# Altium schematic sheet Sheet2.SchDoc(Sheet2)
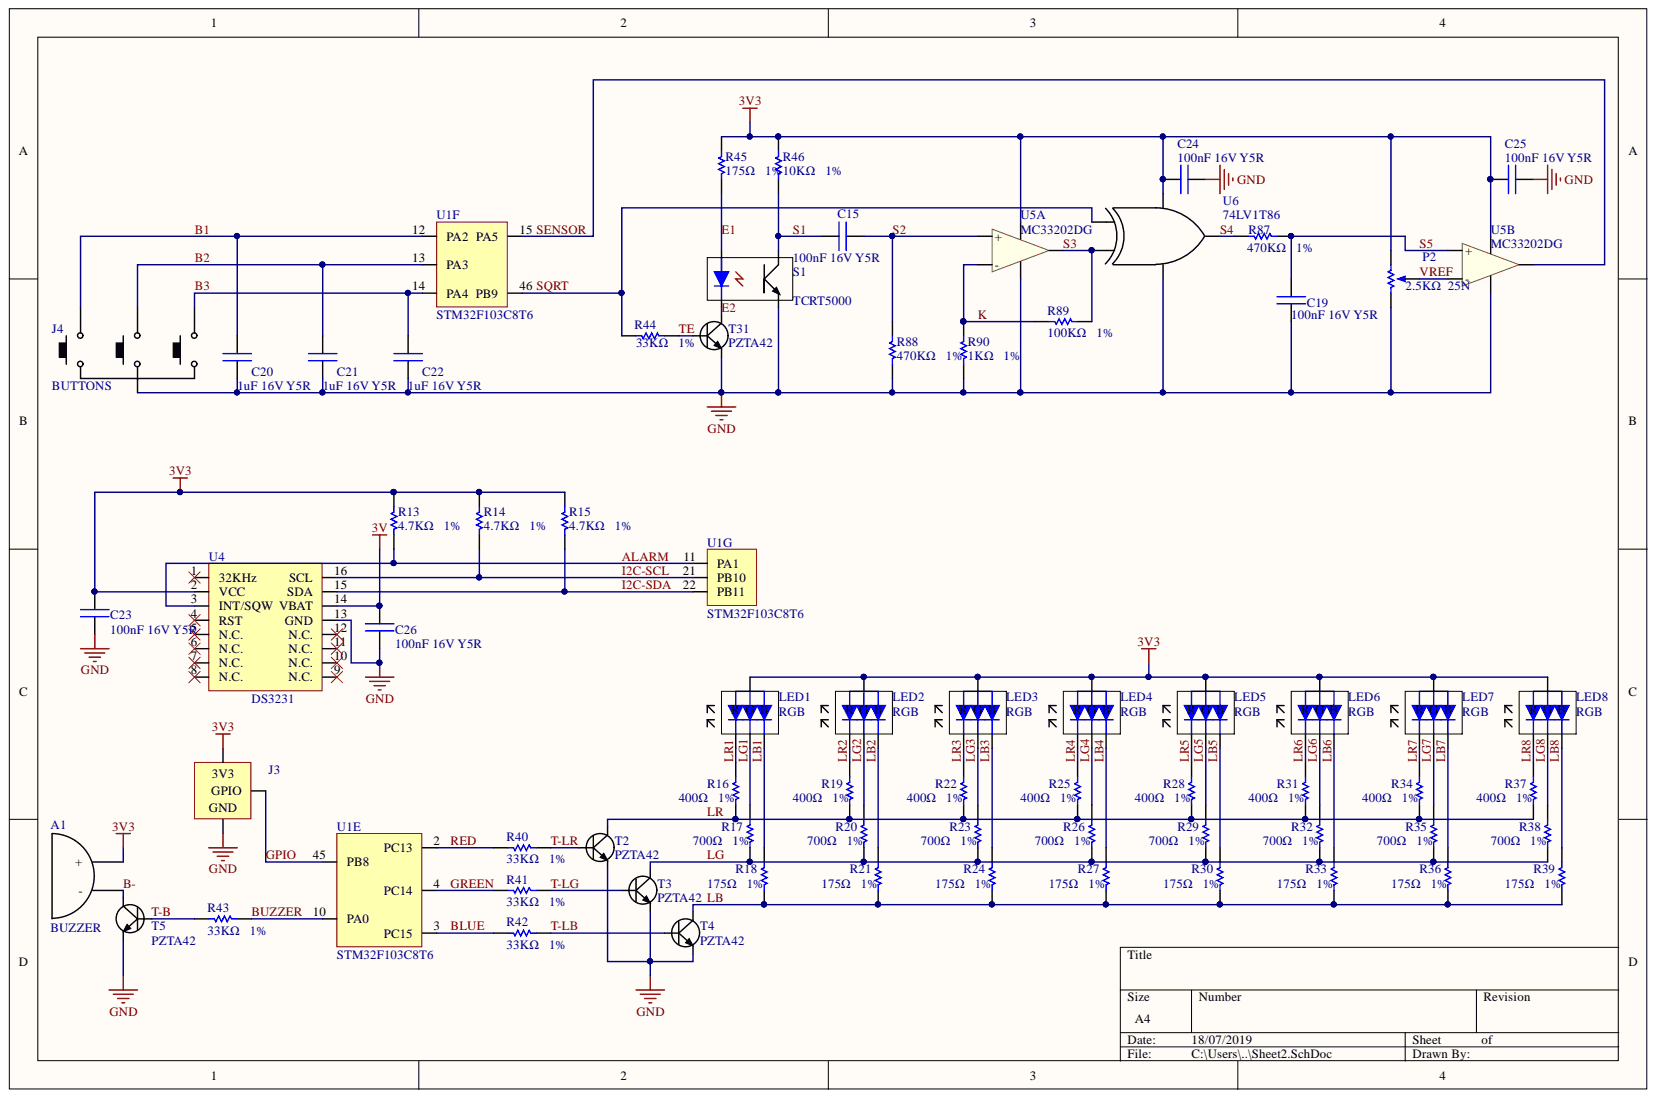
[diagram p0: Sheet2.SchDoc]

## Parts
A1  BUZZER  [BUZZER]
C15  100nF 16V Y5R  [0603]
C19  100nF 16V Y5R  [0603]
C20  1uF 16V Y5R  [0603]
C21  1uF 16V Y5R  [0603]
C22  1uF 16V Y5R  [0603]
C23  100nF 16V Y5R  [0603]
C24  100nF 16V Y5R  [0603]
C25  100nF 16V Y5R  [0603]
C26  100nF 16V Y5R  [0603]
J3  GPIO  [PIN-HEADERx3]
J4  BUTTONS  [BORNERAx4]
LED1  RGB  [1205-RGB]
LED2  RGB  [1205-RGB]
LED3  RGB  [1205-RGB]
LED4  RGB  [1205-RGB]
LED5  RGB  [1205-RGB]
LED6  RGB  [1205-RGB]
LED7  RGB  [1205-RGB]
LED8  RGB  [1205-RGB]
P2  2.5KΩ  25N  [3296W]
R13  4.7KΩ   1%  [0603]
R14  4.7KΩ   1%  [0603]
R15  4.7KΩ   1%  [0603]
R16  400Ω   1%  [0603]
R17  700Ω   1%  [0603]
R18  175Ω   1%  [0603]
R19  400Ω   1%  [0603]
R20  700Ω   1%  [0603]
R21  175Ω   1%  [0603]
R22  400Ω   1%  [0603]
R23  700Ω   1%  [0603]
R24  175Ω   1%  [0603]
R25  400Ω   1%  [0603]
R26  700Ω   1%  [0603]
R27  175Ω   1%  [0603]
R28  400Ω   1%  [0603]
R29  700Ω   1%  [0603]
R30  175Ω   1%  [0603]
R31  400Ω   1%  [0603]
R32  700Ω   1%  [0603]
R33  175Ω   1%  [0603]
R34  400Ω   1%  [0603]
R35  700Ω   1%  [0603]
R36  175Ω   1%  [0603]
R37  400Ω   1%  [0603]
R38  700Ω   1%  [0603]
R39  175Ω   1%  [0603]
R40  33KΩ   1%  [0603]
R41  33KΩ   1%  [0603]
R42  33KΩ   1%  [0603]
R43  33KΩ   1%  [0603]
R44  33KΩ   1%  [0603]
R45  175Ω   1%  [0603]
R46  10KΩ   1%  [0603]
R87  470KΩ   1%  [0603]
R88  470KΩ   1%  [0603]
R89  100KΩ   1%  [0603]
R90  1KΩ   1%  [0603]
S1  TCRT5000  [BORNERAx4]
T2  PZTA42  [SOT-23]
T3  PZTA42  [SOT-23]
T4  PZTA42  [SOT-23]
T5  PZTA42  [SOT-23]
T31  PZTA42  [SOT-23]
U1  STM32F103C8T6  [LQFP48]  (parts E,F,G)
U4  DS3231  [SO16W]
U5  MC33202DG  [SOIC-8]  (parts A,B)
U6  74LV1T86  [SOT-23-5]

## Nets
3V: C26.2 U4.14(VBAT)
3V3: A1.1 C23.2 C24.2 C25.2 J3.1 LED1.2(G) LED2.2(G) LED3.2(G) LED4.2(G) LED5.2(G) LED6.2(G) LED7.2(G) LED8.2(G) P2.1 R13.2 R14.2 R15.2 R45.2 R46.2 U4.2(VCC) U5.8 U6.5
GND: C19.1 C20.1 C21.1 C22.1 C23.1 C24.1 C25.1 C26.1 J3.3 J4.4 P2.3 R88.1 R90.2 S1.4 T2.2 T3.2 T4.2 T5.2 T31.2 U4.13(GND) U5.4 U6.3
ALARM: R13.1 U1.11(PA1) U4.3(INT/SQW)
B1: C20.2 J4.1 U1.12(PA2)
B2: C21.2 J4.2 U1.13(PA3)
B3: C22.2 J4.3 U1.14(PA4)
B-: A1.2 T5.3
BLUE: R42.1 U1.3(PC15)
BUZZER: R43.2 U1.10(PA0)
E1: R45.1 S1.1
E2: S1.2 T31.3
GPIO: J3.2 U1.45(PB8)
GREEN: R41.1 U1.4(PC14)
I2C-SCL: R14.1 U1.21(PB10) U4.16(SCL)
I2C-SDA: R15.1 U1.22(PB11) U4.15(SDA)
K: R89.2 R90.1 U5.2(-)
LB: R18.1 R21.1 R24.1 R27.1 R30.1 R33.1 R36.1 R39.1 T4.3
LB1: LED1.3(B) R18.2
LB2: LED2.3(B) R21.2
LB3: LED3.3(B) R24.2
LB4: LED4.3(B) R27.2
LB5: LED5.3(B) R30.2
LB6: LED6.3(B) R33.2
LB7: LED7.3(B) R36.2
LB8: LED8.3(B) R39.2
LG: R17.1 R20.1 R23.1 R26.1 R29.1 R32.1 R35.1 R38.1 T3.3
LG1: LED1.4 R17.2
LG2: LED2.4 R20.2
LG3: LED3.4 R23.2
LG4: LED4.4 R26.2
LG5: LED5.4 R29.2
LG6: LED6.4 R32.2
LG7: LED7.4 R35.2
LG8: LED8.4 R38.2
LR: R16.1 R19.1 R22.1 R25.1 R28.1 R31.1 R34.1 R37.1 T2.3
LR1: LED1.1(R) R16.2
LR2: LED2.1(R) R19.2
LR3: LED3.1(R) R22.2
LR4: LED4.1(R) R25.2
LR5: LED5.1(R) R28.2
LR6: LED6.1(R) R31.2
LR7: LED7.1(R) R34.2
LR8: LED8.1(R) R37.2
RED: R40.1 U1.2(PC13)
S1: C15.1 R46.1 S1.3
S2: C15.2 R88.2 U5.3(+)
S3: R89.1 U5.1 U6.2
S4: R87.1 U6.4
S5: C19.2 R87.2 U5.5
SENSOR: U1.15(PA5) U5.7
SQRT: R44.2 U1.46(PB9) U6.1
T-B: R43.1 T5.1
T-LB: R42.2 T4.1
T-LG: R41.2 T3.1
T-LR: R40.2 T2.1
TE: R44.1 T31.1
VREF: P2.2 U5.6

Not connected: U4 1(32KHz) 4(RST) 5(N.C.) 6(N.C.) 7(N.C.) 8(N.C.) 9(N.C.) 10(N.C.) 11(N.C.) 12(N.C.)

## Library data of the parts
C15 C19-C26 = CAP: Component Kind: Standard; Library Name: Nixielib.SchLib
J4 = BUTTONx3: Component Kind: Standard; Library Name: Nixielib.SchLib
P2 = POTE: Component Kind: Standard; Library Name: Nixielib.SchLib
R13-R46 R87-R90 = RES: Component Kind: Standard; Library Name: Nixielib.SchLib
S1 = E/R infrarojo: Component Kind: Standard; Library Name: Nixielib.SchLib
T2-T5 T31 = NPN: Component Kind: Standard; Library Name: Nixielib.SchLib
U5 = Operacional: Component Kind: Standard; Library Name: Nixielib.SchLib
U6 = XOR: Component Kind: Standard; Library Name: Nixielib.SchLib
A1 = BUZZER: Component Kind: Standard; Library Name: Nixielib.SchLib
J3 = GPIO: Component Kind: Standard; Library Name: Nixielib.SchLib
LED1-LED8 = RGB: Component Kind: Standard; Library Name: Nixielib.SchLib
U1 = STM32F103C8T6: Component Kind: Standard; Library Name: Nixielib.SchLib
U4 = DS3231: Component Kind: Standard; Library Name: Nixielib.SchLib
